# Altium schematic sheet aethernode.SchDoc
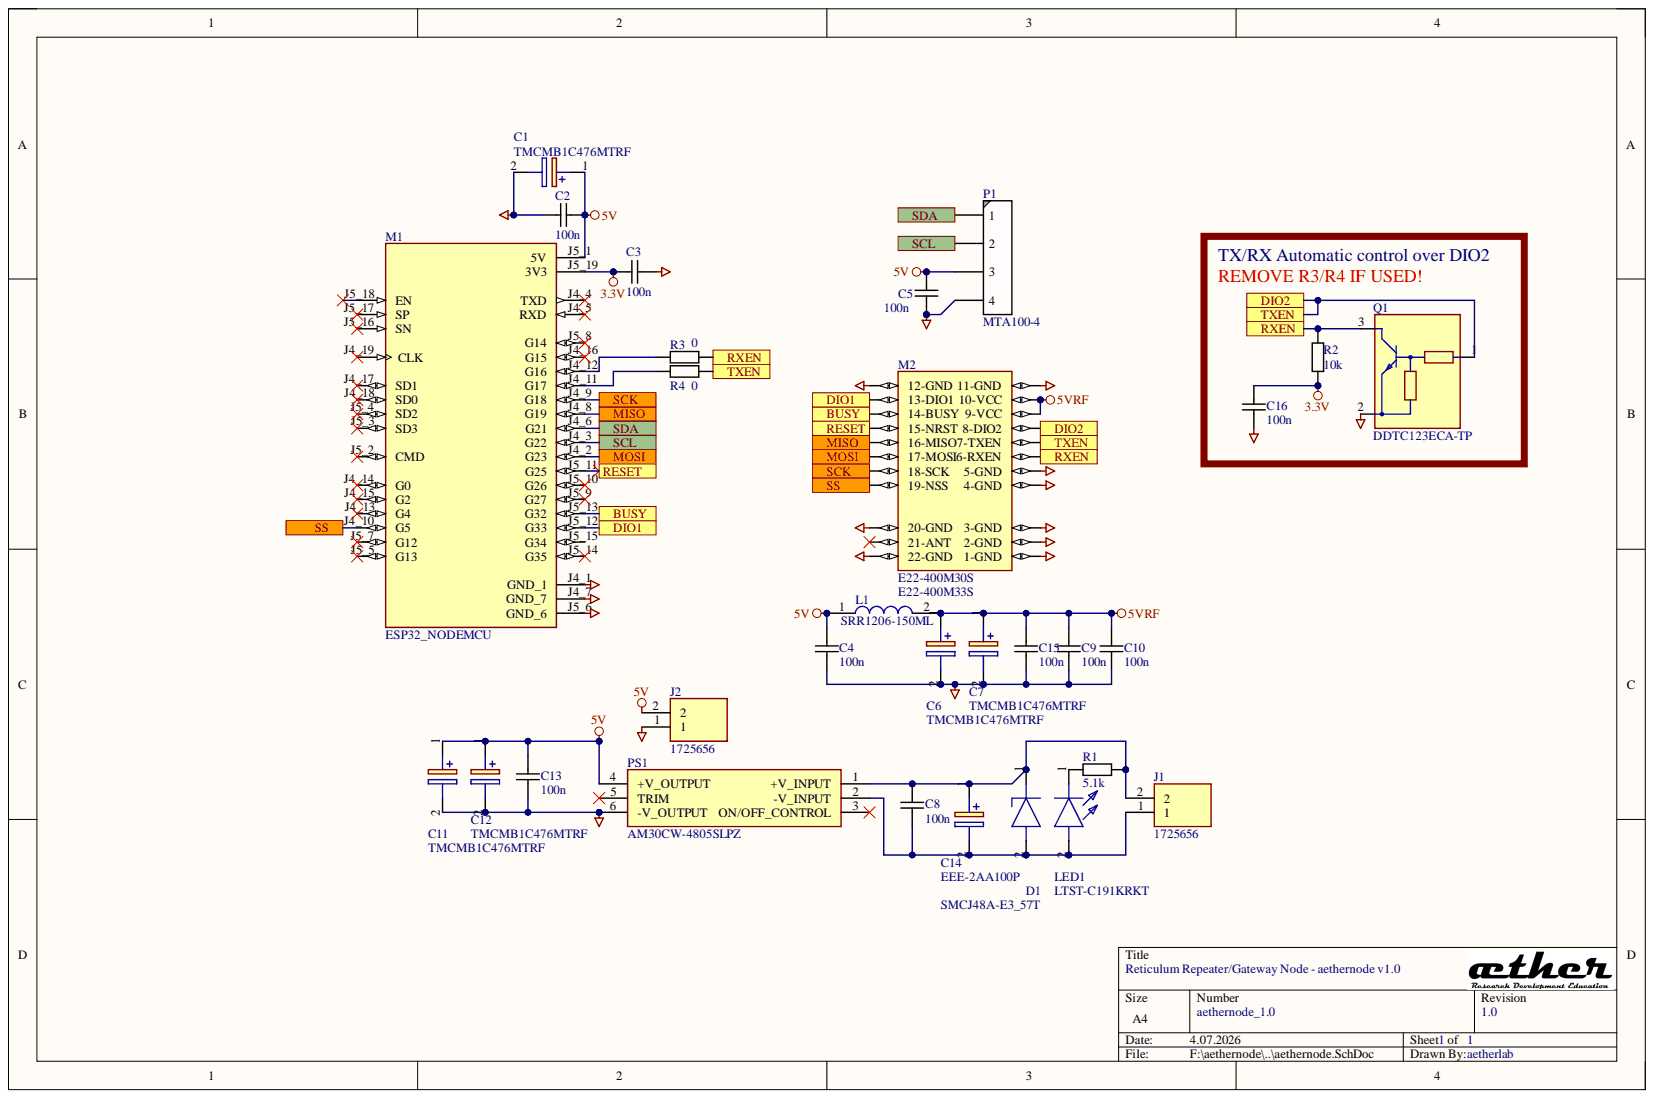
[diagram p0: aethernode.SchDoc]

## Parts
C1  TMCMB1C476MTRF  [TMCMB1C476MTRF]
C2  100n  [C1206]
C3  100n  [C1206]
C4  100n  [C1206]
C5  100n  [C1206]
C6  TMCMB1C476MTRF  [TMCMB1C476MTRF]
C7  TMCMB1C476MTRF  [TMCMB1C476MTRF]
C8  100n  [C1206]
C9  100n  [C1206]
C10  100n  [C1206]
C11  TMCMB1C476MTRF  [TMCMB1C476MTRF]
C12  TMCMB1C476MTRF  [TMCMB1C476MTRF]
C13  100n  [C1206]
C14  EEE-2AA100P  [EEHZT1E331UP]
C15  100n  [C1206]
C16  100n  [C1206]
D1  SMCJ48A-E3_57T  [DIOM7959X262N]
J1  1725656  [1725656]
J2  1725656  [1725656]
L1  SRR1206-150ML  [SRR1206150ML]
LED1  LTST-C191KRKT  [LEDC1608X55N]
M1  ESP32_NODEMCU  [MODULE_ESP32_NODEMCU]
M2  E22-400M33S  [E22-400M30S]
P1  MTA100-4  [MTA100-4]
PS1  AM30CW-4805SLPZ  [AM15CW2405SNZ]
Q1  DDTC123ECA-TP  [SOT95P237X125-3N]
R1  5.1k  [R1206]
R2  10k  [R1206]
R3  0  [R1206]
R4  0  [R1206]

## Ports
BUSY, DIO1, DIO2, MISO, MOSI, RESET, RXEN, SCK, SCL, SDA, SS, TXEN

## Nets
3.3V: C3.2 C16.2 M1.J5_19(3V3) R2.1
5V: C1.1 C2.1 C4.2 C5.2 C11.1 C12.1 C13.2 J2.2 L1.1 M1.J5_1(5V) P1.3 PS1.4(+V_OUTPUT)
5VRF: C6.1 C7.1 C9.2 C10.2 C15.2 L1.2 M2.9-VCC M2.10-VCC
GND: C1.2 C2.2 C3.1 C4.1 C5.1 C6.2 C7.2 C9.1 C10.1 C11.2 C12.2 C13.1 C15.1 C16.1 J2.1 M1.J4_1(GND_1) M1.J4_7(GND_7) M1.J5_6(GND_6) M2.1-GND M2.2-GND M2.3-GND M2.4-GND M2.5-GND M2.11-GND M2.12-GND M2.20-GND M2.22-GND P1.4 PS1.6(-V_OUTPUT) Q1.2
BUSY: M1.J5_13(G32) M2.14-BUSY ; port BUSY
DIO1: M1.J5_12(G33) M2.13-DIO1 ; port DIO1
DIO2: M2.7-TXEN(16-MISO7-TXEN) M2.8-DIO2 Q1.1 R4.2 ; port DIO2, port TXEN
MISO: M1.J4_8(G19) M2.16-MISO ; port MISO
MOSI: M1.J4_2(G23) M2.17-MOSI ; port MOSI
RESET: M1.J5_11(G25) M2.15-NRESET(15-NRST) ; port RESET
RXEN: M2.6-RXEN(17-MOSI6-RXEN) Q1.3 R2.2 R3.2 ; port RXEN
SCK: M1.J4_9(G18) M2.18-SCK ; port SCK
SCL: M1.J4_3(G22) P1.2 ; port SCL
SDA: M1.J4_6(G21) P1.1 ; port SDA
SS: M1.J4_10(G5) M2.19-NSS ; port SS
NetC8_1: C8.1 C14.2 D1.2 J1.1 LED1.2 PS1.2(-V_INPUT)
NetC8_2: C8.2 C14.1 D1.1 J1.2 PS1.1(+V_INPUT) R1.2
NetLED1_1: LED1.1 R1.1
NetM1_J4_11: M1.J4_11(G17) R4.1
NetM1_J4_12: M1.J4_12(G16) R3.1

Not connected: M1 J4_4(TXD) J4_5(RXD) J4_13(G4) J4_14(G0) J4_15(G2) J4_16(G15) J4_17(SD1) J4_18(SD0) J4_19(CLK) J5_2(CMD) J5_3(SD3) J5_4(SD2) J5_5(G13) J5_7(G12) J5_8(G14) J5_9(G27) J5_10(G26) J5_14(G35) J5_15(G34) J5_16(SN) J5_17(SP) J5_18(EN); M2 21-ANT; PS1 3(ON/OFF_CONTROL) 5(TRIM)

## Library data of the parts
C1 C6 C7 C11 C12 = TMCMB1C476MTRF: Capacitor Polarised; Manufacturer_Name: Vishay; Arrow Part Number: TMCMB1C476MTRF; Arrow Price/Stock: https://www.arrow.com/en/products/tmcmb1c476mtrf/vishay?utm_currency=USD&region=nac; Component Kind: Standard; Datasheet Link: https://www.vishay.com/docs/40178/tmcm.pdf; Height: 2.1mm; Manufacturer_Part_Number: TMCMB1C476MTRF; Mouser Part Number: 74-TMCMB1C476MTRF; Mouser Price/Stock: https://www.mouser.co.uk/ProductDetail/Vishay-Sprague/TMCMB1C476MTRF?qs=NgbZBzc1CyEmJWrRgGfNNQ%3D%3D
C2-C5 C8-C10 C13 C15 C16 = Cap: Capacitor; Component Kind: Standard; Library Name: Cap.Schlib
C14 = EEE-2AA100P: Capacitor Polarised; Manufacturer_Name: Panasonic; Arrow Part Number: EEE-2AA100P; Arrow Price/Stock: https://www.arrow.com/en/products/eee-2aa100p/panasonic; Component Kind: Standard; Datasheet Link: http://industrial.panasonic.com/cdbs/www-data/pdf/RDE0000/ABA0000C1145.pdf; Height: 10.8mm; Manufacturer_Part_Number: EEE-2AA100P; Mouser Part Number: 667-EEE-2AA100P; Mouser Price/Stock: https://www.mouser.co.uk/ProductDetail/Panasonic/EEE-2AA100P/?qs=wEytwf7f49GYMnTPlhAEBA%3D%3D
D1 = SMCJ30A-E3_57T: Diode ESD (Uni-directional); Manufacturer_Name: Vishay; Manufacturer_Part_Number: SMCJ30A-E3/57T; Arrow Part Number: SMCJ30A-E3/57T; Arrow Price/Stock: https://www.arrow.com/en/products/smcj30a-e357t/vishay?utm_currency=USD&region=nac; Component Kind: Standard; Datasheet Link: https://componentsearchengine.com/Datasheets/2/SMCJ30A-E3_57T.pdf; Height: 2.62mm; Mouser Part Number: 625-SMCJ30A-E3; Mouser Price/Stock: https://www.mouser.co.uk/ProductDetail/Vishay-General-Semiconductor/SMCJ30A-E3-57T?qs=uJo%252BpYCWgL6rDKZorzUAIg%3D%3D
J1 J2 = 1725656: Connector; Manufacturer_Name: Phoenix Contact; Arrow Part Number: 1725656; Arrow Price/Stock: https://www.arrow.com/en/products/1725656/phoenix-contact?utm_currency=USD&region=nac; Component Kind: Standard; Datasheet Link: https://www.phoenixcontact.com/online/portal/us/?uri=pxc-oc-itemdetail:pid=1725656&library=usen&pcck=P-11-01-05&tab=1&selectedCategory=ALL; Height: 8.65mm; Manufacturer_Part_Number: 1725656; Mouser Part Number: 651-1725656; Mouser Price/Stock: https://www.mouser.co.uk/ProductDetail/Phoenix-Contact/1725656?qs=Ul7CXFMnlWWQeccayYbRmw%3D%3D
L1 = SRR1206-150ML: Inductor; Manufacturer_Name: Bourns; Arrow Part Number: SRR1206-150ML; Arrow Price/Stock: https://www.arrow.com/en/products/srr1206-150ml/bourns?utm_currency=USD&region=nac; Component Kind: Standard; Datasheet Link: https://www.bourns.com/docs/Product-Datasheets/SRR1206.pdf; Height: 6.5mm; Manufacturer_Part_Number: SRR1206-150ML; Mouser Part Number: 652-SRR1206-150ML; Mouser Price/Stock: https://www.mouser.co.uk/ProductDetail/Bourns/SRR1206-150ML?qs=Ad8RhBDoVy8YsO3s7dYR9Q%3D%3D
LED1 = LTST-C191KRKT: LED; Manufacturer_Name: Lite-On; Arrow Part Number: LTST-C191KRKT; Arrow Price/Stock: https://www.arrow.com/en/products/ltst-c191krkt/lite-on-technology?region=nac; Component Kind: Standard; Datasheet Link: http://www.mouser.com/ds/2/239/S_110_LTST-C191KRKT-539838.pdf; Height: 0.55mm; Library Name: SamacSys.SchLib; Manufacturer_Part_Number: LTST-C191KRKT; Mouser Part Number: 859-LTST-C191KRKT; Mouser Price/Stock: https://www.mouser.co.uk/ProductDetail/Lite-On/LTST-C191KRKT?qs=BAIUz4Y8PJBLfOhzue0q%252BA%3D%3D
M1 = ESP32_NODEMCU: NodeMCU is an open source loT platform. ESP32 is a series of low cost, low powersystem-on-chip (SoC) microcontrollers with integrated Wi-Fi and dual-mode Bluetooth.; MF: AZ Delivery; MP: ESP32 NODEMCU; Check_prices: https://www.snapeda.com/parts/ESP32%20NODEMCU/AZ+Displays/view-part/?ref=eda; Component Kind: Standard; Library Name: ESP32_NODEMCU.SchLib; Package: Package; SnapEDA_Link: https://www.snapeda.com/parts/ESP32%20NODEMCU/AZ+Displays/view-part/?ref=snap
M2 = E22-400M30S: SX1268 433/470MHz SPI SMD LoRa Module; MF: EBYTE; Check_prices: https://www.snapeda.com/parts/E22-400M30S/EBYTE/view-part/?ref=eda; Component Kind: Standard; Library Name: E22-400M30S.SchLib; MP: E22-400M30S; Package: Package; SnapEDA_Link: https://www.snapeda.com/parts/E22-400M30S/EBYTE/view-part/?ref=snap
P1 = Header 4: Header, 4-Pin; Component Kind: Standard; Library Name: Header 4.Schlib
PS1 = AM15CW-2405S-NZ: Power Supply; Manufacturer_Name: aimtec; Component Kind: Standard; Datasheet Link: http://www.aimtec.com/site/Aimtec/files/Datasheet/HighResolution/am15cw-nz.pdf?ft4=8-432; Height: 11.95mm; Manufacturer_Part_Number: AM15CW-2405S-NZ
Q1 = DDTC123ECA-TP: Transistor RET NPN; Manufacturer_Name: MCC; Component Kind: Standard; Datasheet Link: https://www.mccsemi.com/pdf/products/DDTC123ECA(SOT-23).pdf; Height: 1.25mm; Manufacturer_Part_Number: DDTC123ECA-TP
R1-R4 = Resistor: Component Kind: Standard; Description: Resistor; Library Name: Res.Schlib
